# Altium schematic sheet CM001_V4_1.SchDoc(CM001_V4_1)
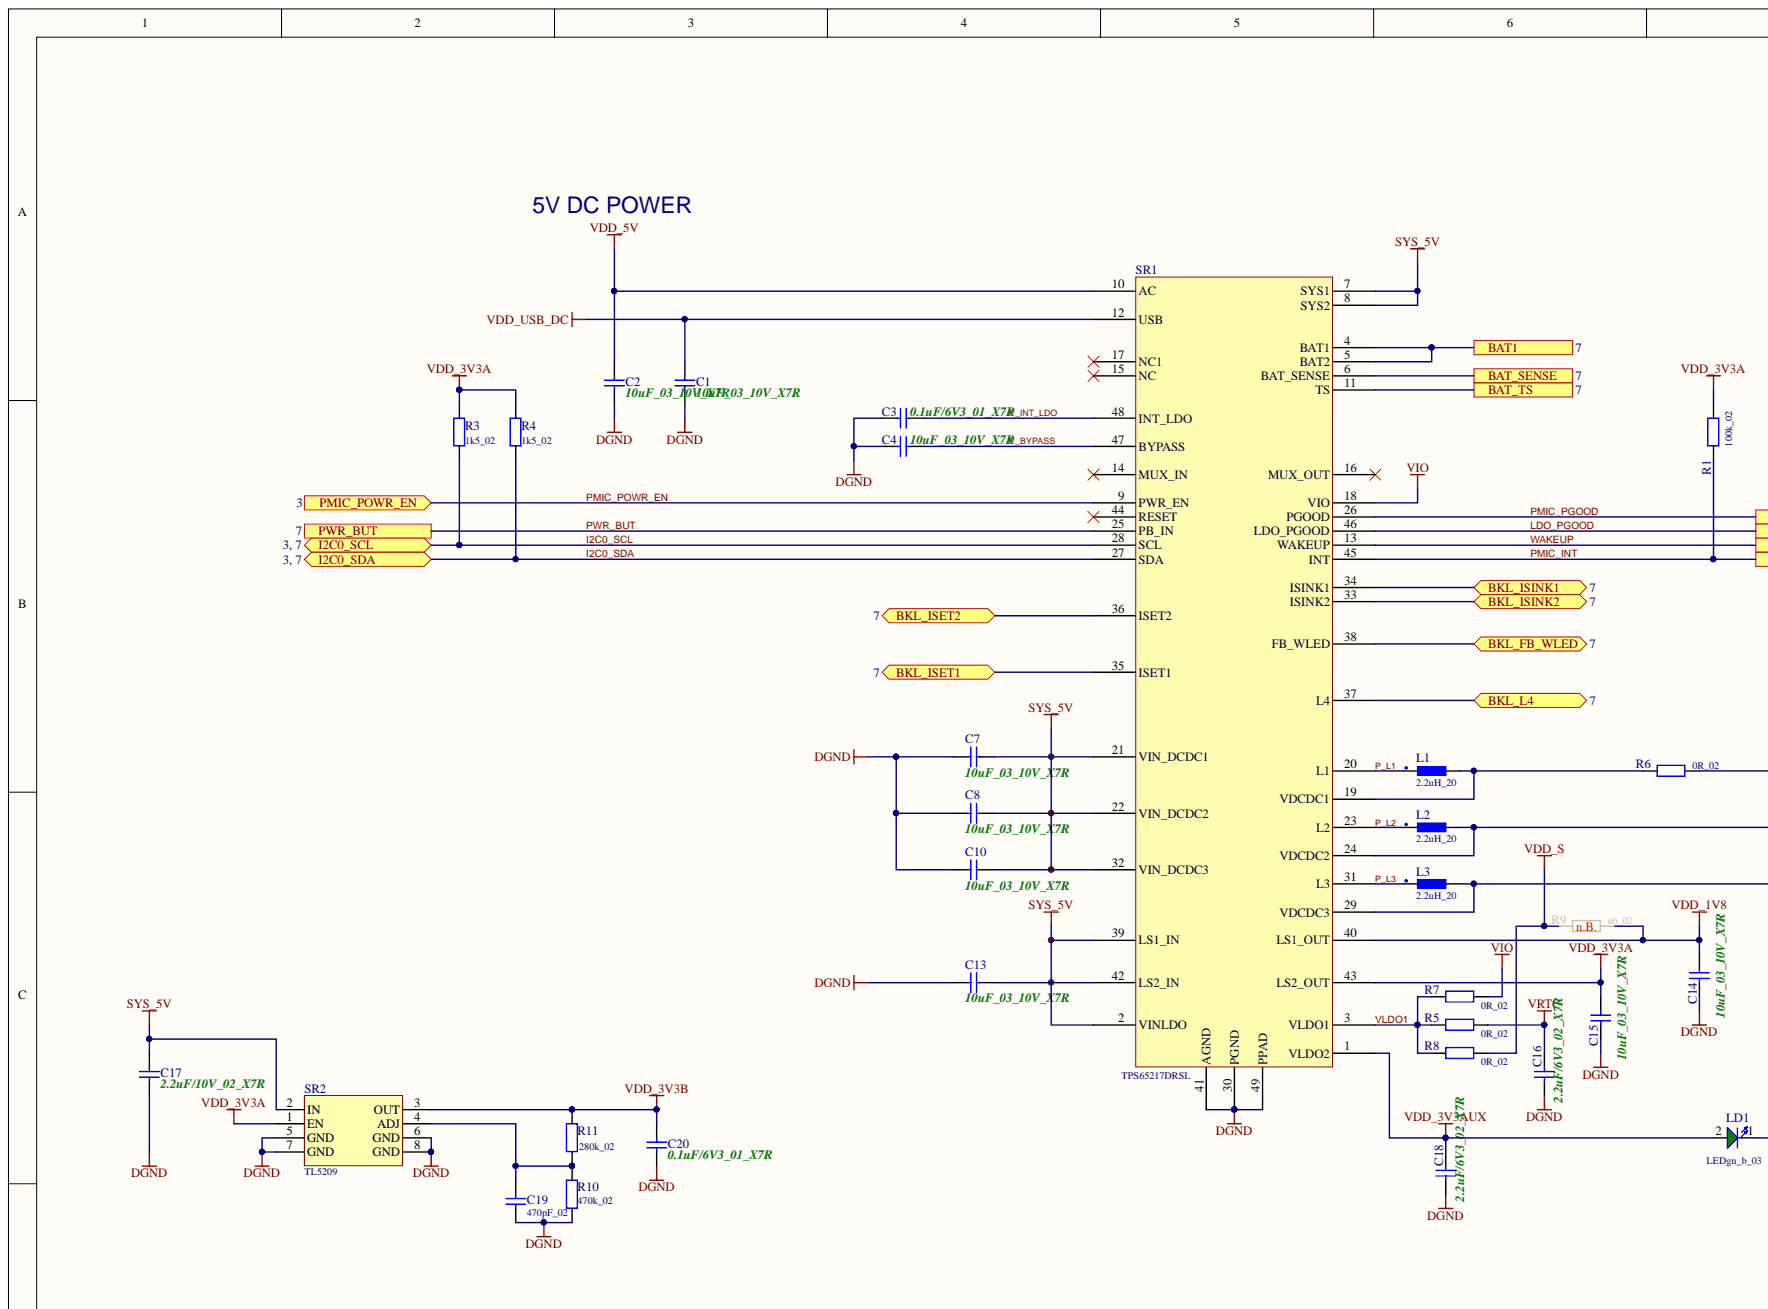
[diagram p0: CM001_V4_1.SchDoc]

## Parts
C1  10uF_03_10V_X7R  [CC1608-0603]
C1  10uF_03_10V_X7R  [CC1608-0603]
C2  10uF_03_10V_X7R  [CC1608-0603]
C2  10uF_03_10V_X7R  [CC1608-0603]
C3  0.1uF/6V3_01_X7R  [CC0603-0201]
C3
C4  10uF_03_10V_X7R  [CC1608-0603]
C4  10uF_03_10V_X7R  [CC1608-0603]
C7  10uF_03_10V_X7R  [CC1608-0603]
C7  10uF_03_10V_X7R  [CC1608-0603]
C8  10uF_03_10V_X7R  [CC1608-0603]
C8  10uF_03_10V_X7R  [CC1608-0603]
C9  10uF_03_10V_X7R  [CC1608-0603]  (value not drawn)
C9  10uF_03_10V_X7R  [CC1608-0603]  (value not drawn)
C10  10uF_03_10V_X7R  [CC1608-0603]
C10  10uF_03_10V_X7R  [CC1608-0603]
C11  10uF_03_10V_X7R  [CC1608-0603]  (value not drawn)
C11  10uF_03_10V_X7R  [CC1608-0603]  (value not drawn)
C12  10uF_03_10V_X7R  [CC1608-0603]  (value not drawn)
C12  10uF_03_10V_X7R  [CC1608-0603]  (value not drawn)
C13  10uF_03_10V_X7R  [CC1608-0603]
C13  10uF_03_10V_X7R  [CC1608-0603]
C14  10uF_03_10V_X7R  [CC1608-0603]
C14  10uF_03_10V_X7R  [CC1608-0603]
C15  10uF_03_10V_X7R  [CC1608-0603]
C15  10uF_03_10V_X7R  [CC1608-0603]
C16  2.2uF/6V3_02_X7R  [CC1005-0402]
C16  2.2uF/6V3_02_X7R  [CC1005-0402]
C17  2.2uF/10V_02_X7R  [CC1005-0402]
C18  2.2uF/6V3_02_X7R  [CC1005-0402]
C18  2.2uF/6V3_02_X7R  [CC1005-0402]
C19  470pF_02  [CC1005-0402]
C20  0.1uF/6V3_01_X7R  [CC0603-0201]
C20
L1  2.2uH_20  [CR9879-2520]
L2  2.2uH_20  [CR9879-2520]
L3  2.2uH_20  [CR9879-2520]
LD1  LEDgn_b_03  [CD1608-0603]
R1  100k_02  [CR1005-0402]
R3  1k5_02  [CR1005-0402]
R4  1k5_02  [CR1005-0402]
R5  0R_02  [CR1005-0402]
R6  0R_02  [CR1005-0402]
R7  0R_02  [CR1005-0402]
R8  0R_02  [CR1005-0402]
R9  nb_02  [CR1005-0402]  (no BOM)
R10  470k_02  [CR1005-0402]
R11  280k_02  [CR1005-0402]
R12  820R_02  [CR1005-0402]  (value not drawn)
SR1  TPS65217DRSL  [RSL48+1_TI_TPS]
SR2  TL5209  [SO8]

## Ports
BAT1, BAT_SENSE, BAT_TS, BKL_FB_WLED, BKL_ISET1, BKL_ISET2, BKL_ISINK1, BKL_ISINK2, BKL_L4, I2C0_SCL, I2C0_SDA, LDO_PGOOD, PMIC_INT, PMIC_PGOOD, PMIC_POWR_EN, PWR_BUT, WAKEUP

## Nets
DGND: C1.1 C2.1 C3.1 C4.1 C7.1 C8.1 C9.1 C10.1 C11.1 C12.1 C13.1 C14.1 C15.1 C16.1 C17.1 C18.1 C19.1 C20.1 R10.1 R12.2 SR1.30(PGND) SR1.41(AGND) SR1.49(PPAD) SR2.5(GND) SR2.6(GND) SR2.7(GND) SR2.8(GND)
SYS_5V: C7.2 C8.2 C10.2 C13.2 C17.2 SR1.2(VINLDO) SR1.7(SYS1) SR1.8(SYS2) SR1.21(VIN_DCDC1) SR1.22(VIN_DCDC2) SR1.32(VIN_DCDC3) SR1.39(LS1_IN) SR1.42(LS2_IN) SR2.2(IN)
VDD_1V8: C14.2 R9.2 SR1.40(LS1_OUT)
VDD_3V3A: C15.2 R1.2 R3.2 R4.2 SR1.43(LS2_OUT) SR2.1(EN)
VDD_3V3AUX: C18.2 LD1.2 SR1.1(VLDO2)
VDD_3V3B: C20.2 R11.2 SR2.3(OUT)
VDD_5V: C2.2 SR1.10(AC)
VDD_CORE: C11.2 L3.2 SR1.29(VDCDC3)
VDD_MPU: C12.2 L2.2 SR1.24(VDCDC2)
VDD_S: R8.2 R9.1
VDD_USB_DC: C1.2 SR1.12(USB)
VDDS_DDR: C9.2 R6.2
VIO: R7.2 SR1.18(VIO)
VRTC: C16.2 R5.2
BAT1: SR1.4(BAT1) SR1.5(BAT2) ; port BAT1
BAT_SENSE: SR1.6(BAT_SENSE) ; port BAT_SENSE
BAT_TS: SR1.11(TS) ; port BAT_TS
BKL_FB_WLED: SR1.38(FB_WLED) ; port BKL_FB_WLED
BKL_ISET1: SR1.35(ISET1) ; port BKL_ISET1
BKL_ISET2: SR1.36(ISET2) ; port BKL_ISET2
BKL_ISINK1: SR1.34(ISINK1) ; port BKL_ISINK1
BKL_ISINK2: SR1.33(ISINK2) ; port BKL_ISINK2
BKL_L4: SR1.37(L4) ; port BKL_L4
I2C0_SCL: R3.1 SR1.28(SCL) ; port I2C0_SCL
I2C0_SDA: R4.1 SR1.27(SDA) ; port I2C0_SDA
LDO_PGOOD: SR1.46(LDO_PGOOD) ; port LDO_PGOOD
P_BYPASS: C4.2 SR1.47(BYPASS)
P_INT_LDO: C3.2 SR1.48(INT_LDO)
P_L1: L1.1 SR1.20(L1)
P_L2: L2.1 SR1.23(L2)
P_L3: L3.1 SR1.31(L3)
PMIC_INT: R1.1 SR1.45(INT) ; port PMIC_INT
PMIC_PGOOD: SR1.26(PGOOD) ; port PMIC_PGOOD
PMIC_POWR_EN: SR1.9(PWR_EN) ; port PMIC_POWR_EN
PWR_BUT: SR1.25(PB_IN) ; port PWR_BUT
PWR_LEDR: LD1.1 R12.1
VLDO1: R5.1 R7.1 R8.1 SR1.3(VLDO1)
WAKEUP: SR1.13(WAKEUP) ; port WAKEUP
NetC19_2: C19.2 R10.2 R11.1 SR2.4(ADJ)
NetL1_2: L1.2 R6.1 SR1.19(VDCDC1)

Not connected: SR1 14(MUX_IN) 15(NC) 16(MUX_OUT) 17(NC1) 44(RESET)

## Library data of the parts
C1 C2 C4 C7 C8 C10 C13 = C10uF_03_10V_X7R: Capacitor 0603 10uF 10V X7R; S/T: SMD; Hinweis: KRU Artikelnummer gelöscht; Art-Nr.: 08.0001.0___; Value: 10uF_03_10V_X7R; Simulation: CAP; Signal Integrity: Cap; Hersteller: *; Component Kind: Standard; Bestellbezeichnung: *; Library Name: C_ies_Integrated_Library.IntLib
C1 C2 C4 C7-C15 = C10uF_03_10V_X7R: Capacitor 0603 10uF 10V X7R; S/T: SMD; Art-Nr.: 08.0001.0___; Hinweis: KRU Artikelnummer gelöscht; Value: 10uF_03_10V_X7R; Simulation: CAP; Signal Integrity: Cap; Hersteller: *; Component Kind: Standard; Bestellbezeichnung: *; Library Name: C_ies_Integrated_Library.IntLib
C3 C20 = C0.1uF/6V3_01_X7R: Capacitor 0201 6,3V X7R; S/T: SMD; Hersteller: any; erstellt von: Finke; Art-Nr.: 08.0002.0___; Produktbeispiel: Gudeco: muRata: GRM033R70J103JA01D; Value: 0.1uF/6V3_01_X7R; Supplier Part Number 2: 8USl_ZajxFVxkJsfRx-2TMMxBpVDD3GHQuOpPGq7oYPTEIlO4Ffw3x4_A6bjf4Mm; Supplier Part Number 1: 490-3167-6-ND; Supplier 2: Arrow; Supplier 1: Digi-Key; Component Kind: Standard; Bestellbezeichnung: *; Library Name: C_ies_Integrated_Library.IntLib
C9 C11 C12 C14 C15 = C10uF_03_10V_X7R: Capacitor 0603 10uF 10V X7R; S/T: SMD; erstellt von: MIE; Art-Nr.: 08.0001.0___; Hinweis: KRU Artikelnummer gelöscht; Value: 10uF_03_10V_X7R; Simulation: CAP; Signal Integrity: Cap; Revision: July-2002: Re-released for DXP Platform.; Hersteller: *; Component Kind: Standard; Bestellbezeichnung: *; Library Name: C_ies_Integrated_Library.IntLib
C16 C18 = C2.2uF/6V3_02_X7R: Capacitor 0402 6V3 X7R; S/T: SMD; Art-Nr.: 08.0002.; erstellt von: Fin; Value: 2.2uF/6V3_02_X7R; Simulation: CAP; Signal Integrity: Cap; Revision: July-2002: Re-released for DXP Platform.; Hersteller: *; Component Kind: Standard; Bestellbezeichnung: *; Library Name: C_ies_Integrated_Library.IntLib
C16 C18 = C2.2uF/6V3_02_X7R: Capacitor 0402 6V3 X7R; S/T: SMD; erstellt von: Fin; Art-Nr.: 08.0002.; Value: 2.2uF/6V3_02_X7R; Simulation: CAP; Signal Integrity: Cap; Revision: July-2002: Re-released for DXP Platform.; Hersteller: *; Component Kind: Standard; Bestellbezeichnung: *; Library Name: C_ies_Integrated_Library.IntLib
C17 = C2.2uF/10V_02_X7R: Capacitor 0402 10V X7R; S/T: SMD; Art-Nr.: 08.0002.___; Value: 2.2uF/10V_02_X7R; Simulation: CAP; Signal Integrity: Cap; Revision: July-2002: Re-released for DXP Platform.; Hersteller: *; Component Kind: Standard; Bestellbezeichnung: *; Library Name: C_ies_Integrated_Library.IntLib
C19 = C470pF_02: Capacitor 0402 50V X7R; S/T: SMD; erstellt von: MIE; Art-Nr.: 08.0002.; Value: 470pF_02; Component Kind: Standard; Library Name: C_ies_Integrated_Library.IntLib
L1-L3 = L2.2uH_20: Inductor 2520; 2,2uH; 1,3A; S/T: SMD; Hersteller: MURATA; Bestellbezeichnung: LQM2HPN2R2MG0L; Art-Nr.: 12.0501.; Value: 2.2uH_20; Component Kind: Standard; Library Name: L_ies_Integrated_Library.IntLib
LD1 = LD_LEDgn_bright_03: Leuchtdiode bright grün 0603, RV(5mA)@3V3= 100OHM; S/T: SMD; Lieferant: Würth Elektronik; Bestellbezeichnung: 150060VS75000; Art-Nr.: 05.0401.0022; Value: LEDgn_b_03; Hersteller: Würth Elektronik; ComponentLink1Description: LEDge_03 Datenblatt; Component Kind: Standard; Library Name: LD_ies_Integrated_Library.IntLib; ComponentLink1URL: u:\LD_Leuchtdioden\Wuerth\150060VS75000.pdf
R1 = R100k_02: Resistor; 0402; 1%; S/T: SMD; Art-Nr.: 09.0002.0003; Value: 100k_02; Component Kind: Standard; Library Name: R_ies_Integrated_Library.IntLib
R3 R4 = R1k5_02: Resistor; 0402; 1%; S/T: SMD; Art-Nr.: 09.0002.0028; Value: 1k5_02; Revision: July-2002: Re-released for DXP Platform.; Component Kind: Standard; Library Name: R_ies_Integrated_Library.IntLib
R5-R8 = R0R_02: Resistor; 0402; 5%; S/T: SMD; Art-Nr.: 09.0002.0002; Value: 0R_02; Supplier Part Number 2: Digi-Key - 311-0.0JRCT-ND; Supplier Part Number 1: 311-0.0JRCT-ND; Supplier 2: Octopart; Supplier 1: Digi-Key; Component Kind: Standard; Library Name: R_ies_Integrated_Library.IntLib
R9 = RnB_02: Resistor; 0402; S/T: SMD; Value: nb_02; Library Name: R_ies_Integrated_Library.IntLib
R10 = R470k_02: Resistor; 0402; 1%; S/T: SMD; Art-Nr.: 09.0002.0023; Value: 470k_02; Component Kind: Standard; Library Name: R_ies_Integrated_Library.IntLib
R11 = R280k_02: Resistor; 0402; 1%; S/T: SMD; Art-Nr.: 09.0002.; Value: 280k_02; Component Kind: Standard; Library Name: R_ies_Integrated_Library.IntLib
R12 = R820R_02: Resistor; 0402; 1%; S/T: SMD; Art-Nr.: 09.0002.; Value: 820R_02; Component Kind: Standard; Library Name: R_ies_Integrated_Library.IntLib
SR1 = SRTPS65217DRSL: TPS65217x Single-Chip PMIC for Battery-Powered Systems; S/T: SMD; Hersteller: TI; Art-Nr.: 04.0901.; Value: TPS65217DRSL; ComponentLink1Description: Datenblatt; Component Kind: Standard; Bestellbezeichnung: TPS65217DRSL; Library Name: SR_ies_Integrated_Library.IntLib; ComponentLink1URL: U:\SR_Spannungsregler\Ti\TPS65217D_slvu551i.pdf
SR2 = SRTL5209: IC LDO VOLT REG 500MA 8-SOIC; S/T: SMD; Lieferant: Arrow; Hersteller: TI; erstellt von: Finke; Bestellbezeichnung: TL5209DR; Art-Nr.: 04.0901.; Value: TL5209; ComponentLink1Description: Datenblatt; Component Kind: Standard; Library Name: SR_ies_Integrated_Library.IntLib; ComponentLink1URL: U:\SR_Spannungsregler\Ti\TL5209.pdf
